# Altium schematic sheet BMS.SchDoc
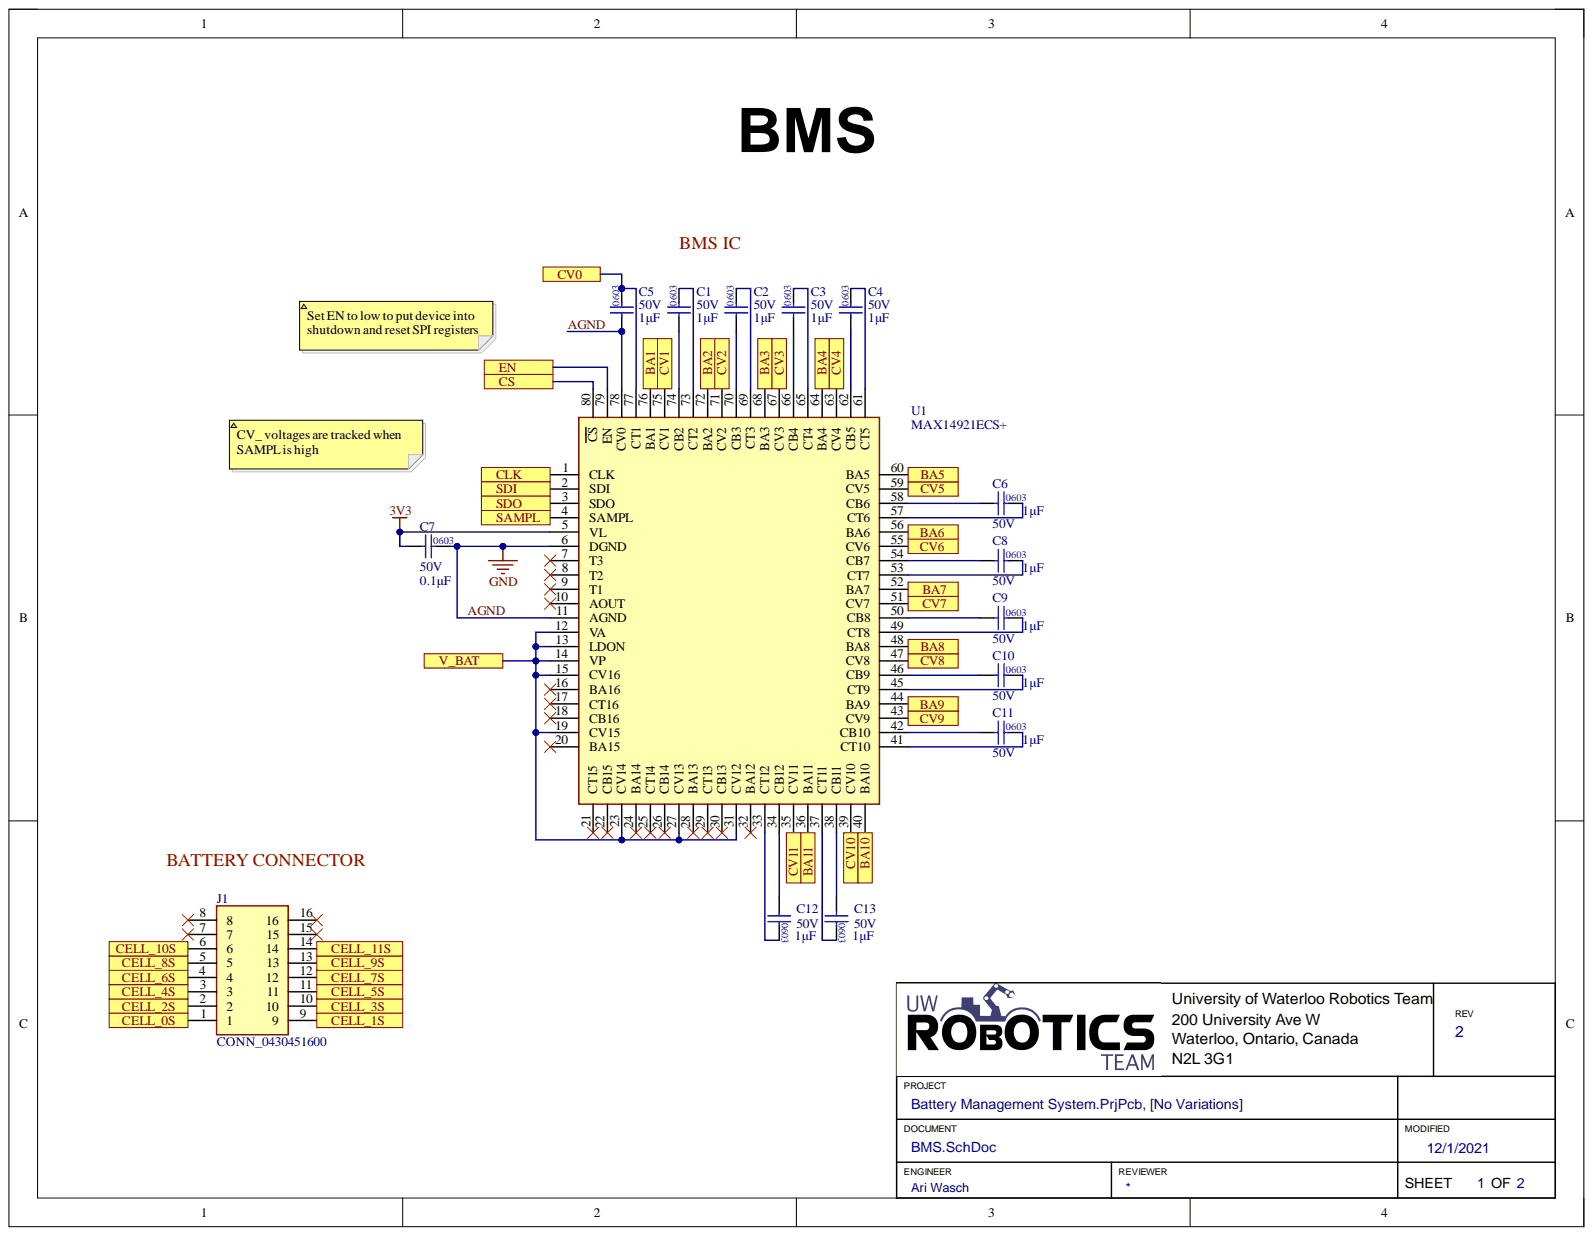
[diagram p0: BMS.SchDoc]

## Parts
C1  value 1μF  Voltage Rating: 50V  [CAP0603]  (hidden comment: CAP_1uF_50V_0603)
C2  value 1μF  Voltage Rating: 50V  [CAP0603]  (hidden comment: CAP_1uF_50V_0603)
C3  value 1μF  Voltage Rating: 50V  [CAP0603]  (hidden comment: CAP_1uF_50V_0603)
C4  value 1μF  Voltage Rating: 50V  [CAP0603]  (hidden comment: CAP_1uF_50V_0603)
C5  value 1μF  Voltage Rating: 50V  [CAP0603]  (hidden comment: CAP_1uF_50V_0603)
C6  value 1μF  Voltage Rating: 50V  [CAP0603]  (hidden comment: CAP_1uF_50V_0603)
C7  value 0.1μF  Package/Footprint: 0603  Voltage Rating: 50V  [CAP0603]  (hidden comment: CAP_0.1uF_50V_0603)
C8  value 1μF  Voltage Rating: 50V  [CAP0603]  (hidden comment: CAP_1uF_50V_0603)
C9  value 1μF  Voltage Rating: 50V  [CAP0603]  (hidden comment: CAP_1uF_50V_0603)
C10  value 1μF  Voltage Rating: 50V  [CAP0603]  (hidden comment: CAP_1uF_50V_0603)
C11  value 1μF  Voltage Rating: 50V  [CAP0603]  (hidden comment: CAP_1uF_50V_0603)
C12  value 1μF  Voltage Rating: 50V  [CAP0603]  (hidden comment: CAP_1uF_50V_0603)
C13  value 1μF  Voltage Rating: 50V  [CAP0603]  (hidden comment: CAP_1uF_50V_0603)
J1  CONN_0430451600  [0430451600]  (value not drawn)
U1  MAX14921ECS+  [QFP50P1400X1400X120-80N]

## Ports
BA1, BA2, BA3, BA4, BA5, BA6, BA7, BA8, BA9, BA10, BA11, CELL_0S, CELL_1S, CELL_2S, CELL_3S, CELL_4S, CELL_5S, CELL_6S, CELL_7S, CELL_8S, CELL_9S, CELL_10S, CELL_11S, CLK, CS, CV0, CV1, CV2, CV3, CV4, CV5, CV6, CV7, CV8, CV9, CV10, CV11, EN, SAMPL, SDI, SDO, V_BAT

## Nets
3V3: C7.1 U1.5(VL)
AGND: C5.1 C7.2 U1.6(DGND) U1.11(AGND) U1.78(CV0)
BA1: U1.76(BA1) ; port BA1
BA2: U1.72(BA2) ; port BA2
BA3: U1.68(BA3) ; port BA3
BA4: U1.64(BA4) ; port BA4
BA5: U1.60(BA5) ; port BA5
BA6: U1.56(BA6) ; port BA6
BA7: U1.52(BA7) ; port BA7
BA8: U1.48(BA8) ; port BA8
BA9: U1.44(BA9) ; port BA9
BA10: U1.40(BA10) ; port BA10
BA11: U1.36(BA11) ; port BA11
CELL_0S: J1.1 ; port CELL_0S
CELL_1S: J1.9 ; port CELL_1S
CELL_2S: J1.2 ; port CELL_2S
CELL_3S: J1.10 ; port CELL_3S
CELL_4S: J1.3 ; port CELL_4S
CELL_5S: J1.11 ; port CELL_5S
CELL_6S: J1.4 ; port CELL_6S
CELL_7S: J1.12 ; port CELL_7S
CELL_8S: J1.5 ; port CELL_8S
CELL_9S: J1.13 ; port CELL_9S
CELL_10S: J1.6 ; port CELL_10S
CELL_11S: J1.14 ; port CELL_11S
CLK: U1.1(CLK) ; port CLK
CS: U1.80(CS) ; port CS
CV0: C5.2 U1.77(CT1) ; port CV0
CV1: U1.75(CV1) ; port CV1
CV2: U1.71(CV2) ; port CV2
CV3: U1.67(CV3) ; port CV3
CV4: U1.63(CV4) ; port CV4
CV5: U1.59(CV5) ; port CV5
CV6: U1.55(CV6) ; port CV6
CV7: U1.51(CV7) ; port CV7
CV8: U1.47(CV8) ; port CV8
CV9: U1.43(CV9) ; port CV9
CV10: U1.39(CV10) ; port CV10
CV11: U1.35(CV11) ; port CV11
EN: U1.79(EN) ; port EN
SAMPL: U1.4(SAMPL) ; port SAMPL
SDI: U1.2(SDI) ; port SDI
SDO: U1.3(SDO) ; port SDO
V_BAT: U1.12(VA) U1.13(LDON) U1.14(VP) U1.15(CV16) U1.19(CV15) U1.23(CV14) U1.27(CV13) U1.31(CV12) ; port V_BAT
NetC1_1: C1.1 U1.74(CB2)
NetC1_2: C1.2 U1.73(CT2)
NetC2_1: C2.1 U1.70(CB3)
NetC2_2: C2.2 U1.69(CT3)
NetC3_1: C3.1 U1.66(CB4)
NetC3_2: C3.2 U1.65(CT4)
NetC4_1: C4.1 U1.62(CB5)
NetC4_2: C4.2 U1.61(CT5)
NetC6_1: C6.1 U1.58(CB6)
NetC6_2: C6.2 U1.57(CT6)
NetC8_1: C8.1 U1.54(CB7)
NetC8_2: C8.2 U1.53(CT7)
NetC9_1: C9.1 U1.50(CB8)
NetC9_2: C9.2 U1.49(CT8)
NetC10_1: C10.1 U1.46(CB9)
NetC10_2: C10.2 U1.45(CT9)
NetC11_1: C11.1 U1.42(CB10)
NetC11_2: C11.2 U1.41(CT10)
NetC12_1: C12.1 U1.34(CB12)
NetC12_2: C12.2 U1.33(CT12)
NetC13_1: C13.1 U1.38(CB11)
NetC13_2: C13.2 U1.37(CT11)

Not connected: J1 7 8 15 16; U1 7(T3) 8(T2) 9(T1) 10(AOUT) 16(BA16) 17(CT16) 18(CB16) 20(BA15) 21(CT15) 22(CB15) 24(BA14) 25(CT14) 26(CB14) 28(BA13) 29(CT13) 30(CB13) 32(BA12)

## Library data of the parts
C1-C6 C8-C13 = CAP_1uF_50V_0603: CAP CER 1UF 50V X6S 0603; Manufacturer 1: TDK Corporation; Manufacturer Part Number 1: C1608X6S1H105K080AC; Component Kind: Standard; Dielectric: X6S; Supplier 1: Digi-Key; Supplier Part Number 1: 445-7424-1-ND; Library Name: UWRT_Schematic_Library_2020.SchLib
C7 = CAP_0.1uF_50V_0603: CAP CER 0.1UF 50V X7R 0603; Manufacturer 1: Yageo; Manufacturer Part Number 1: CC0603KRX7R9BB104; Component Kind: Standard; Dielectric: X7R; Mounting Type: SMD; Supplier 1: Digi-Key; Supplier Part Number 1: 311-1344-1-ND; Library Name: UWRT_Schematic_Library_2020.SchLib
J1 = CONN_0430451600: Connector 16 Pin AJX; Manufacturer_Name: Molex; Arrow Part Number: 0430451600; Arrow Price/Stock: https://www.arrow.com/en/products/0430451600/molex; Component Kind: Standard; Datasheet Link: https://www.molex.com/pdm_docs/sd/430451600_sd.pdf; Height: 9.02mm; Manufacturer_Part_Number: 0430451600; Library Name: UWRT_Schematic_Library_2020.SchLib
U1 = MAX14921ECS+: IC 16s BMS; Manufacturer_Name: Maxim Integrated; Component Kind: Standard; Datasheet Link: http://pdfserv.maximintegrated.com/package_dwgs/21-0072.PDF; Height: 1.2mm; Manufacturer_Part_Number: MAX14921ECS+; Mouser Part Number: 700-MAX14921ECS+; Mouser Price/Stock: https://www.mouser.co.uk/ProductDetail/Maxim-Integrated/MAX14921ECS%2b?qs=Vwh6e7toGLhlnKi5DjCusg%3D%3D; Library Name: UWRT_Schematic_Library_2020.SchLib
